# Altium schematic sheet [3] TopLevel.SchDoc
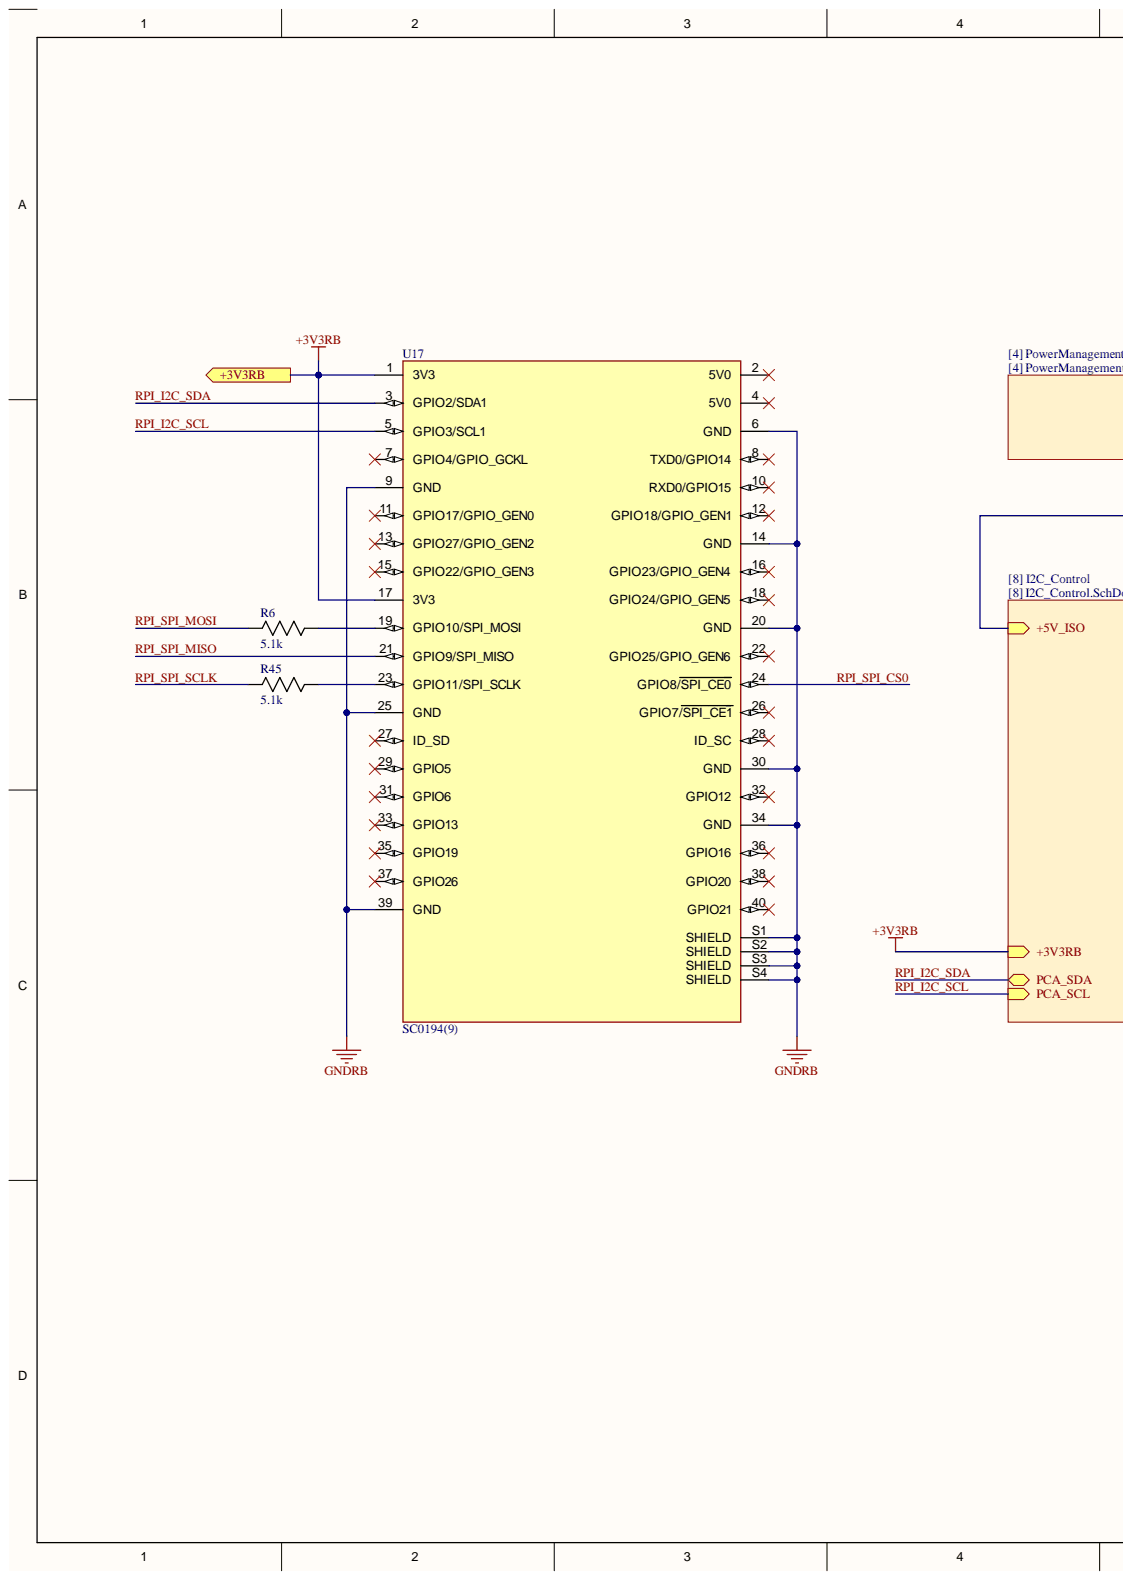
[diagram p0: [3] TopLevel.SchDoc, left]
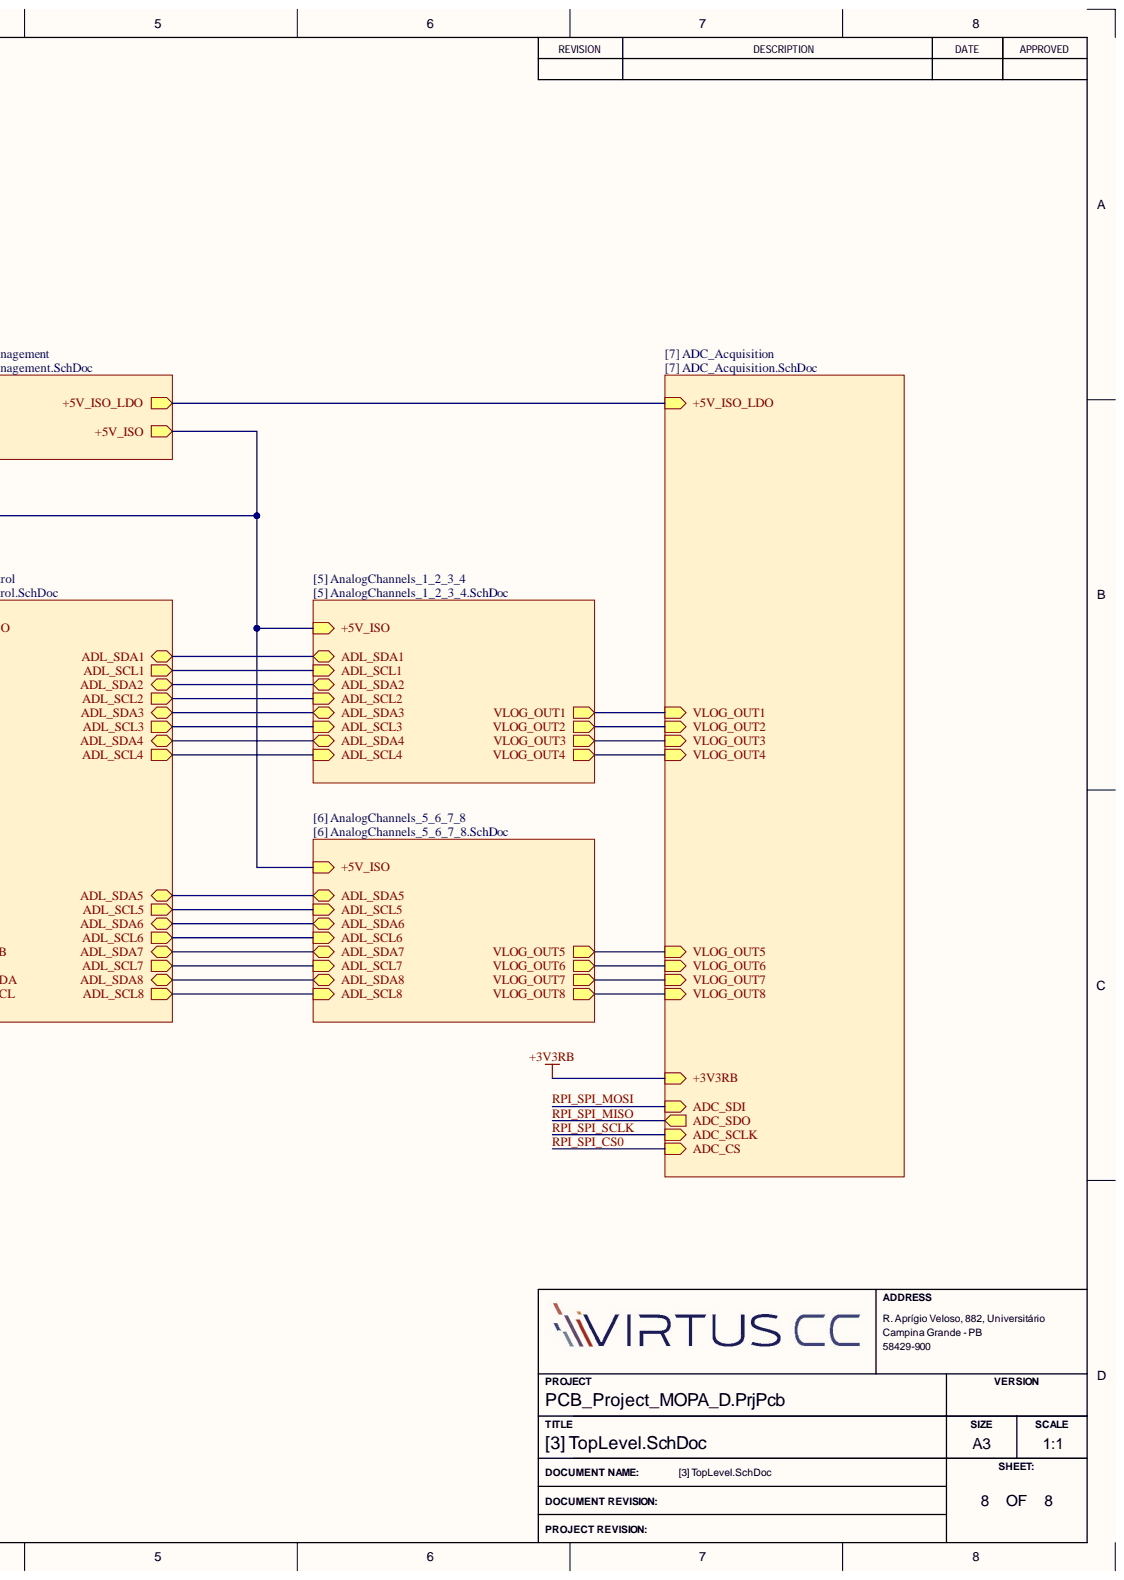
[diagram p1: [3] TopLevel.SchDoc, right]

## Parts
R6  value 5.1k  [RC0402N_YAG]  (hidden comment: RC0402FR-075K1L)
R45  value 5.1k  [RC0402N_YAG]  (hidden comment: RC0402FR-075K1L)
U17  SC0194(9)  [MODULE_SC0194(9)]

## Ports
+3V3RB

## Nets
GNDRB: U17.6(GND) U17.9(GND) U17.14(GND) U17.20(GND) U17.25(GND) U17.30(GND) U17.34(GND) U17.39(GND) U17.S1(SHIELD) U17.S2(SHIELD) U17.S3(SHIELD) U17.S4(SHIELD)
+3V3RB: U17.1(3V3) U17.17(3V3) ; port +3V3RB
RPI_I2C_SCL: U17.5(GPIO3/SCL1)
RPI_I2C_SDA: U17.3(GPIO2/SDA1)
RPI_SPI_CS0: U17.24(GPIO8/SPI_CE0)
RPI_SPI_MISO: U17.21(GPIO9/SPI_MISO)
RPI_SPI_MOSI: R6.1
RPI_SPI_SCLK: R45.1
NetR6_2: R6.2 U17.19(GPIO10/SPI_MOSI)
NetR45_2: R45.2 U17.23(GPIO11/SPI_SCLK)
NetU17_2: U17.2(5V0)
NetU17_4: U17.4(5V0)
NetU17_7: U17.7(GPIO4/GPIO_GCKL)
NetU17_8: U17.8(TXD0/GPIO14)
NetU17_10: U17.10(RXD0/GPIO15)
NetU17_11: U17.11(GPIO17/GPIO_GEN0)
NetU17_12: U17.12(GPIO18/GPIO_GEN1)
NetU17_13: U17.13(GPIO27/GPIO_GEN2)
NetU17_15: U17.15(GPIO22/GPIO_GEN3)
NetU17_16: U17.16(GPIO23/GPIO_GEN4)
NetU17_18: U17.18(GPIO24/GPIO_GEN5)
NetU17_22: U17.22(GPIO25/GPIO_GEN6)
NetU17_26: U17.26(GPIO7/SPI_CE1)
NetU17_27: U17.27(ID_SD)
NetU17_28: U17.28(ID_SC)
NetU17_29: U17.29(GPIO5)
NetU17_31: U17.31(GPIO6)
NetU17_32: U17.32(GPIO12)
NetU17_33: U17.33(GPIO13)
NetU17_35: U17.35(GPIO19)
NetU17_36: U17.36(GPIO16)
NetU17_37: U17.37(GPIO26)
NetU17_38: U17.38(GPIO20)
NetU17_40: U17.40(GPIO21)
+5V_ISO:
+5V_ISO_LDO:
ADL_SCL1:
ADL_SCL2:
ADL_SCL3:
ADL_SCL4:
ADL_SCL5:
ADL_SCL6:
ADL_SCL7:
ADL_SCL8:
ADL_SDA1:
ADL_SDA2:
ADL_SDA3:
ADL_SDA4:
ADL_SDA5:
ADL_SDA6:
ADL_SDA7:
ADL_SDA8:
VLOG_OUT1:
VLOG_OUT2:
VLOG_OUT3:
VLOG_OUT4:
VLOG_OUT5:
VLOG_OUT6:
VLOG_OUT7:
VLOG_OUT8:

## Library data of the parts
R6 R45 = RC0402FR-075K1L: Description; Manufacturer_Name: Yageo; Component Kind: Standard; Manufacturer_Part_Number: RC0402FR-075K1L; Copyright: Copyright (C) 2025 Ultra Librarian. All rights reserved.; Library Name: PCEIOT.SCHLIB
U17 = SC0194(9): The Raspberry Pi 4 Model B SC0194(9) single board computer features a Broadcom BCM2711 ARM Cortex-A72 quad-core processor operating at 1.5GHz, making it a powerful choice for embedded computing applications. Equipped with 4GB of RAM and a variety of conne; Check_prices: https://www.snapeda.com/parts/SC0194(9)/Raspberry+Pi/view-part/?ref=eda; Component Kind: Standard; MF: Raspberry Pi; MP: SC0194(9); Package: None; SnapEDA_Link: https://www.snapeda.com/parts/SC0194(9)/Raspberry+Pi/view-part/?ref=snap; Library Name: PCEIOT.SCHLIB
